# Altium schematic sheet 13_B_T_B_IO.SchDoc(13_B_T_B_IO)
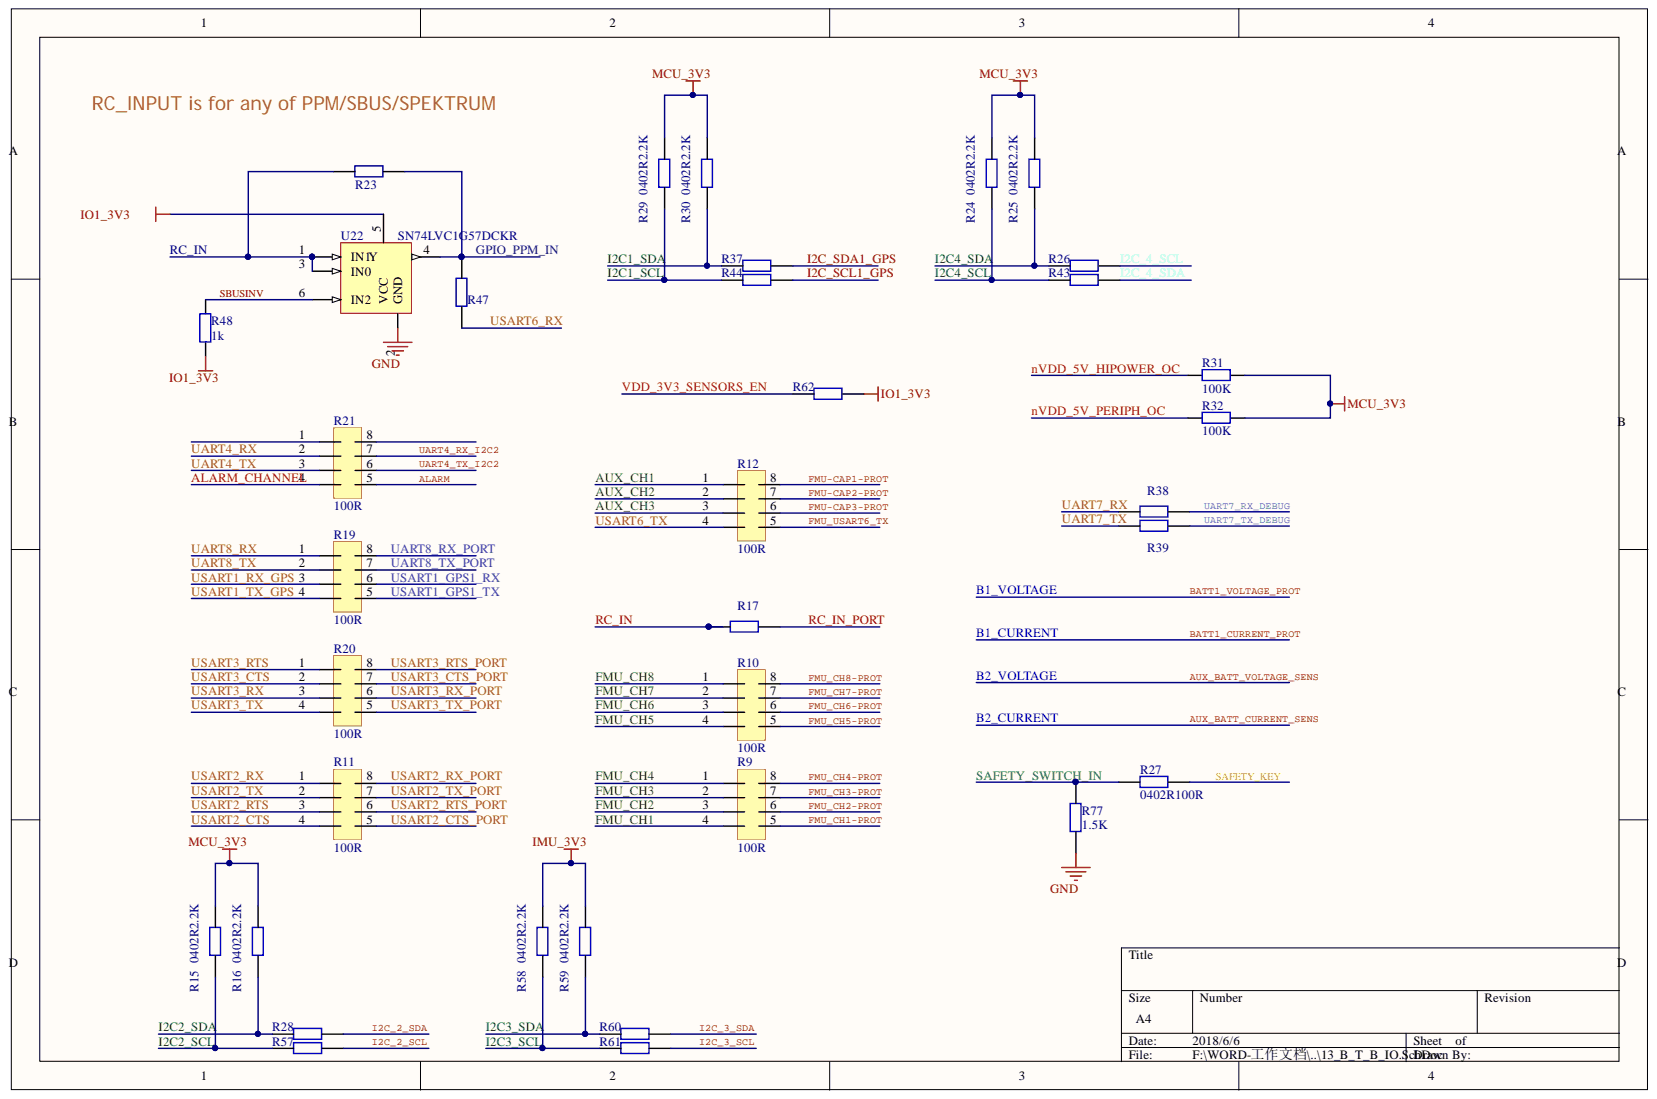
[diagram p0: 13_B_T_B_IO.SchDoc]

## Parts
R9  100R  [0402_4r]
R10  100R  [0402_4r]
R11  100R  [0402_4r]
R12  100R  [0402_4r]
R15  0402R2.2K  [0402R]
R16  0402R2.2K  [0402R]
R17  0402R100R  [0402R]
R19  100R  [0402_4r]
R20  100R  [0402_4r]
R21  100R  [0402_4r]
R23  100R/NC  [0402R]  (value not drawn)
R24  0402R2.2K  [0402R]
R25  0402R2.2K  [0402R]
R26  0402R100R  [0402R]
R27  0402R100R  [0402R]
R28  0402R100R  [0402R]
R29  0402R2.2K  [0402R]
R30  0402R2.2K  [0402R]
R31  100K  [R0402]
R32  100K  [R0402]
R37  0402R100R  [0402R]
R38  0402R100R  [0402R]
R39  0402R100R  [0402R]
R43  0402R100R  [0402R]
R44  0402R100R  [0402R]
R47  100R  [0402R]  (value not drawn)
R48  1k  [R0402]
R57  0402R100R  [0402R]
R58  0402R2.2K  [0402R]
R59  0402R2.2K  [0402R]
R60  0402R100R  [0402R]
R61  0402R100R  [0402R]
R62  10K/NC  [0402R]  (value not drawn)
R77  1.5K  [0402R]
U22  SN74LVC1G57DCKR  [sot323-6]

## Nets
GND: R77.1 U22.2(GND)
IMU_3V3: R58.2 R59.2
IO1_3V3: R48.1 R62.2 U22.5
MCU_3V3: R15.2 R16.2 R24.2 R25.2 R29.2 R30.2 R31.2 R32.2
ALARM: R21.5
ALARM_CHANNEL: R21.4
AUX_CH1: R12.1
AUX_CH2: R12.2
AUX_CH3: R12.3
FMU-CAP1-PROT: R12.8
FMU-CAP2-PROT: R12.7
FMU-CAP3-PROT: R12.6
FMU_CH1: R9.4
FMU_CH1-PROT: R9.5
FMU_CH2: R9.3
FMU_CH2-PROT: R9.6
FMU_CH3: R9.2
FMU_CH3-PROT: R9.7
FMU_CH4: R9.1
FMU_CH4-PROT: R9.8
FMU_CH5: R10.4
FMU_CH5-PROT: R10.5
FMU_CH6: R10.3
FMU_CH6-PROT: R10.6
FMU_CH7: R10.2
FMU_CH7-PROT: R10.7
FMU_CH8: R10.1
FMU_CH8-PROT: R10.8
FMU_USART6_TX: R12.5
GPIO_PPM_IN: R23.1 R47.2 U22.4
I2C1_SCL: R29.1 R44.1
I2C1_SDA: R30.1 R37.1
I2C2_SCL: R15.1 R57.1
I2C2_SDA: R16.1 R28.1
I2C3_SCL: R58.1 R61.1
I2C3_SDA: R59.1 R60.1
I2C4_SCL: R24.1 R43.1
I2C4_SDA: R25.1 R26.1
I2C_2_SCL: R57.2
I2C_2_SDA: R28.2
I2C_3_SCL: R61.2
I2C_3_SDA: R60.2
I2C_4_SCL: R26.2
I2C_4_SDA: R43.2
I2C_SCL1_GPS: R44.2
I2C_SDA1_GPS: R37.2
NVDD_5V_HIPOWER_OC: R31.1
NVDD_5V_PERIPH_OC: R32.1
RC_IN: R17.1 R23.2 U22.1(IN1) U22.3(IN0)
RC_IN_PORT: R17.2
SAFETY_KEY: R27.2
SAFETY_SWITCH_IN: R27.1 R77.2
SBUSINV: R48.2 U22.6(IN2)
UART4_RX: R21.2
UART4_RX_I2C2: R21.7
UART4_TX: R21.3
UART4_TX_I2C2: R21.6
UART7_RX: R38.1
UART7_RX_DEBUG: R38.2
UART7_TX: R39.1
UART7_TX_DEBUG: R39.2
UART8_RX: R19.1
UART8_RX_PORT: R19.8
UART8_TX: R19.2
UART8_TX_PORT: R19.7
USART1_GPS1_RX: R19.6
USART1_GPS1_TX: R19.5
USART1_RX_GPS: R19.3
USART1_TX_GPS: R19.4
USART2_CTS: R11.4
USART2_CTS_PORT: R11.5
USART2_RTS: R11.3
USART2_RTS_PORT: R11.6
USART2_RX: R11.1
USART2_RX_PORT: R11.8
USART2_TX: R11.2
USART2_TX_PORT: R11.7
USART3_CTS: R20.2
USART3_CTS_PORT: R20.7
USART3_RTS: R20.1
USART3_RTS_PORT: R20.8
USART3_RX: R20.3
USART3_RX_PORT: R20.6
USART3_TX: R20.4
USART3_TX_PORT: R20.5
USART6_RX: R47.1
USART6_TX: R12.4
VDD_3V3_SENSORS_EN: R62.1
AUX_BATT_CURRENT_SENS:
AUX_BATT_VOLTAGE_SENS:
B1_CURRENT:
B1_VOLTAGE:

Not connected: R21 1 8

## Library data of the parts
R9-R12 R19-R21 = 0402-8R-4R: Component Kind: Standard; Library Name: CUAV.SchLib
R15 R16 R24 R25 R29 R30 R58 R59 = 0402R10K: 0402R10K 1%; Component Kind: Standard; Library Name: CUAV.SchLib
R17 R23 R26-R28 R37-R39 R43 R44 R47 R57 R60-R62 R77 = 0402R100R: 0402R100R 1%; Component Kind: Standard; Library Name: CUAV.SchLib
R31 R32 = Res2: Resistor; Value: 1K; Component Kind: Standard; LatestRevisionDate: 17-Jul-2002; LatestRevisionNote: Re-released for DXP Platform.; Library Name: Miscellaneous Devices.IntLib; PackageDescription: Axial Device, Thru-Hole; 2 Leads; 0.4 in Pin Spacing; PackageReference: AXIAL-0.4; Published: 8-Jun-2000; Signal Integrity: Res; Simulation: RESISTOR; Publisher: Altium Limited
R48 = Res2: Resistor; Component Kind: Standard; LatestRevisionDate: 17-Jul-2002; LatestRevisionNote: Re-released for DXP Platform.; Library Name: Miscellaneous Devices.IntLib; PackageDescription: Axial Device, Thru-Hole; 2 Leads; 0.4 in Pin Spacing; PackageReference: AXIAL-0.4; Published: 8-Jun-2000; Signal Integrity: Res; Simulation: RESISTOR; Value: 1K; Publisher: Altium Limited
U22 = 74LVC1G57: Component Kind: Standard; Library Name: My_Altium.SchLib
